# Altium schematic sheet WaterLevelSensor.SchDoc
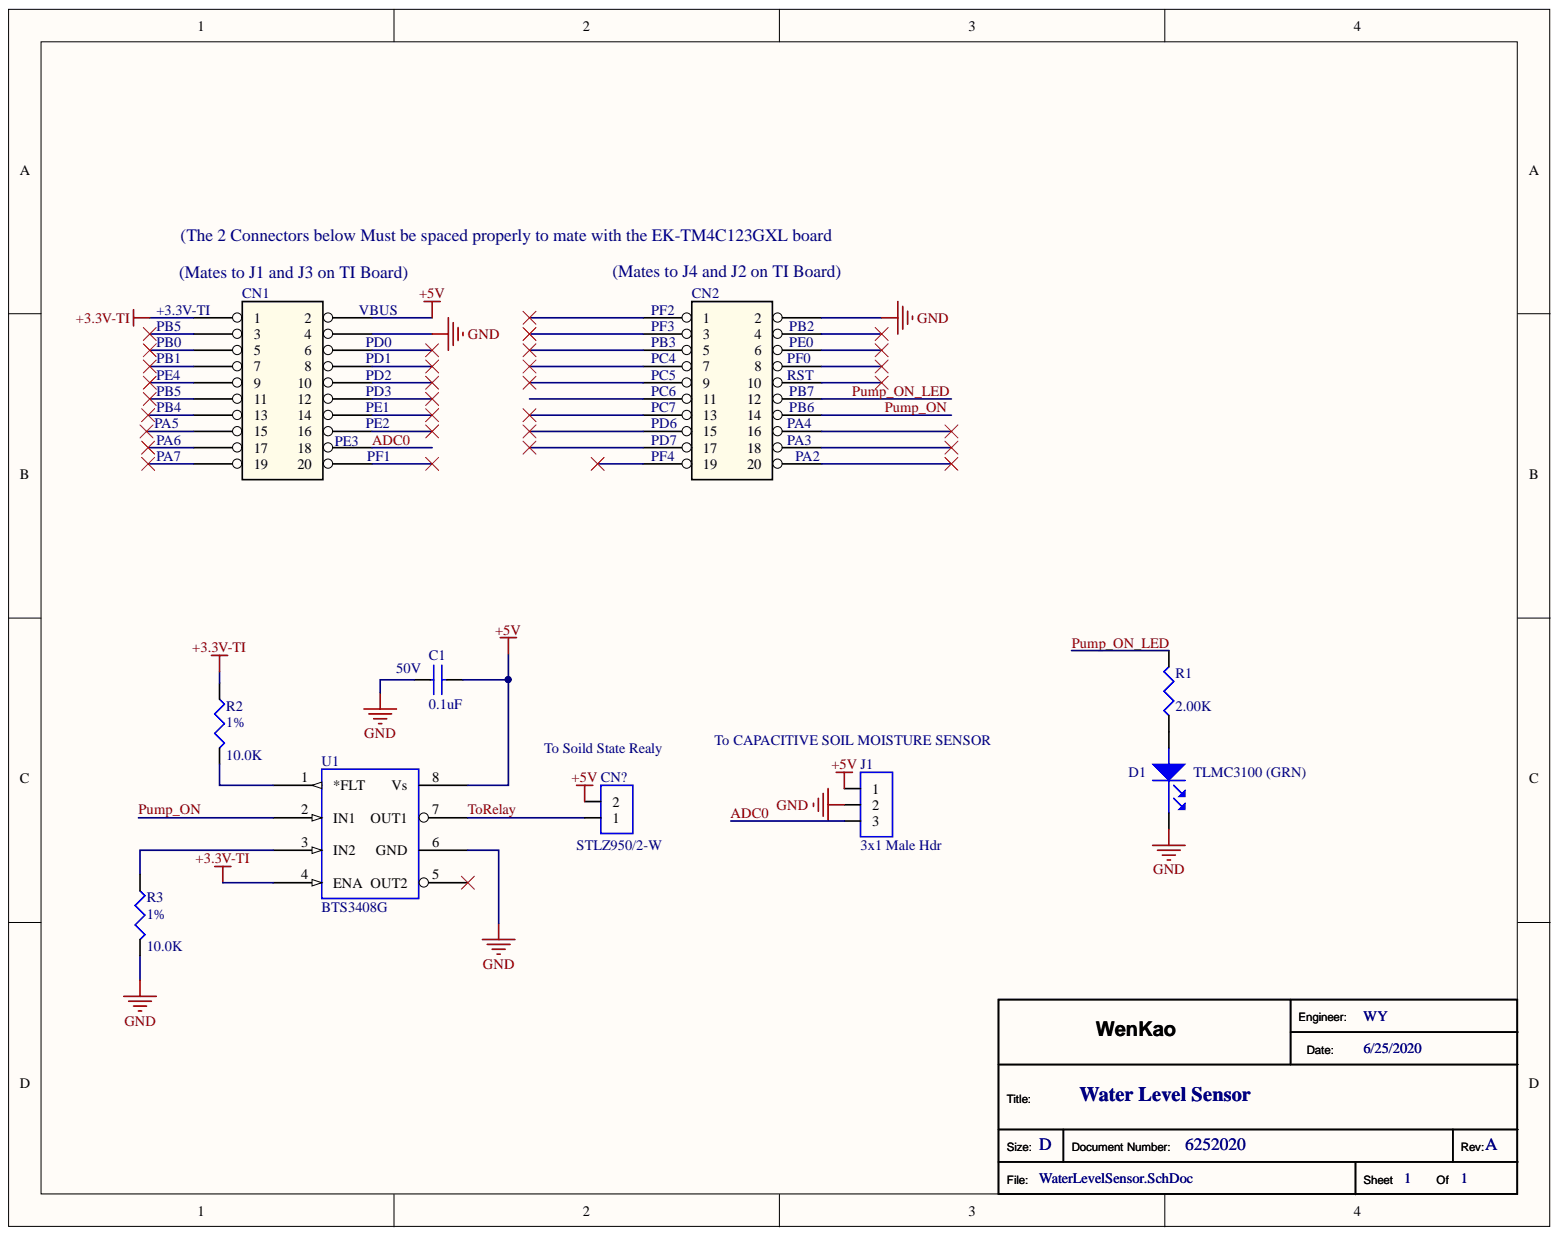
[diagram p0: WaterLevelSensor.SchDoc]

## Parts
C1  0.1uF  Voltage: 50V  [0805]
CN1  HDR 20 Pin  [77324]  (value not drawn)
CN2  HDR 20 Pin  [77324]  (value not drawn)
CN?  Part Number: STLZ950/2-W  [77472l]  (hidden comment: CONN TH HDR MALE 2 x 1, 0.2" R/A 77472 PCB)
D1  TLMC3100 (GRN)  Part Number: TLMC3100  [71072]
J1  3x1 Male Hdr  [70012]
R1  value 2.00K  [0805]  (hidden comment: RES SM D 2.00K 1/10W 0805 1% 01_72577)
R2  value 10.0K  Tolerance: 1%  [0805]  (hidden comment: RES SM E 10.0K 1/10W 0805 1% 72593)
R3  value 10.0K  Tolerance: 1%  [0805]  (hidden comment: RES SM E 10.0K 1/10W 0805 1% 72593)
TitleBlock  TITLE_BLOCK_A  (value not drawn)
U1  BTS3408G  [72343]

## Nets
+3.3V-TI: CN1.1 R2.1 U1.4(ENA)
+5V: C1.2 CN1.2 CN?.2 J1.1 U1.8(Vs)
GND: C1.1 CN1.4 CN2.2 D1.2 J1.2 R3.2 U1.6(GND)
ADC0: CN1.18 J1.3
PUMP_ON: CN2.14 U1.2(IN1)
PUMP_ON_LED: CN2.12 R1.2
TORELAY: CN?.1 U1.7(OUT1)
NetD1_1: D1.1 R1.1
NetR2_2: R2.2 U1.1(*FLT)
NetR3_1: R3.1 U1.3(IN2)

Not connected: CN1 3 5 6 7 8 9 10 11 12 13 14 15 16 17 19 20; CN2 1 3 4 5 6 7 8 9 10 11 13 15 16 17 18 19 20; U1 5(OUT2)

## Library data of the parts
C1 = CAP NP 0.1uf 50V 10% Cer 70969 SMT 0805: Part Name: Cap., SMD, Ceramic, 0805, 50V, 10%, 0.1uF; Part Number: VJ0805Y104KXAM; Vendor PartNo2: 08055C104KAT2A; Vendor PartNo3: 08055C104JAT; Vendor PartNo4: 08052R104K9BB0D; Vendor PartNo5: VJ0805Y104KAMR; ACCOUNT_NUMBER_INVMSTR: 04-001285; BIN_LOCATION_INVMSTR: 24-E-3; BUYER_INVMSTR: 01; CategoryID: 3; CLASS_INVMSTR: CAP; CONTROL_METHOD_INVMSTR: RQ; CONVERSION_INVMSTR: 0; DATE_LAST_COUNT_INVMSTR: 20160104; DATE_LAST_PURCHASED_INVMSTR: 20160425; DESCRIPTION_INVMSTR: CAP 0.1MFD 50V MONLILITC CERAMIC CHIP; Discontinued: False; EXTENDED_TOTAL_INVMSTR: 1455.22; FCI: 70969; LAST_UNIT_COST_INVMSTR: 0.029; LAST_VENDOR_INVMSTR: 108345; LEAD_TIME_INVMSTR: 30; LOCATION_CODE_INVMSTR: 04; REFERENCE_INVMSTR: SYS7; STD_AVG_FLAG_INVMSTR: S; UOM_INVMSTR: EA; USAGE_LAST_YEAR_INVMSTR: 58898; VENDOR_CODE_2_INVMSTR: 106404; VendorID: 222; VendorID2: 239; VendorID4: 61; CATEGORY_INVMSTR: 01; Component Kind: Standard; FootprintType: 0805; FUTURE_EFF_DATE_INVMSTR: 0; FUTURE_STD_COST_INVMSTR: 0; MANUFACT_HOURS_INVMSTR: 0; PHYSICAL_COUNT_INVMSTR: 0; PLANNER_INVMSTR: 01; PRICE_CHANGE_INVMSTR: 15; QUANTITY_OBLIG_INVMSTR: 39768; QUANTITY_ON_HAND_INVMSTR: 50180; QUANTITY_ORDERED_INVMSTR: 0; QUANTITY_REORDER_INVMSTR: 0; QUANTITY_YTD_INVMSTR: 9213; STOCK_LEVEL_MIN_INVMSTR: 0; STOCK_LEVEL_REQ_INVMSTR: 0; UNIT_COST_INVMSTR: 0.029; UNIT_WEIGHT_INVMSTR: 0; Unit Price: 0.01; VENDOR_CODE_1_INVMSTR: 108345; VendorID3: 239; VendorID5: 222; Library Name: FIRECOM_FCI_Library.IntLib
CN1 CN2 = CON HDR MALE 10x2 77324: HDR 0.1'' Male 10x2; ACCOUNT_NUMBER_INVMSTR: 04-001285; BUYER_INVMSTR: 01; CLASS_INVMSTR: CONN; CONTROL_METHOD_INVMSTR: RQ; CONVERSION_INVMSTR: 0; DESCRIPTION_INVMSTR: CON HEADER  - .1" MALE 20 2X10; LEAD_TIME_INVMSTR: 30; LOCATION_CODE_INVMSTR: 04; STD_AVG_FLAG_INVMSTR: S; UOM_INVMSTR: EA; CATEGORY_INVMSTR: 01; Component Kind: Standard; DATE_LAST_COUNT_INVMSTR: 0; DATE_LAST_PURCHASED_INVMSTR: 0; EXTENDED_TOTAL_INVMSTR: 0; FCI: 77324; FUTURE_EFF_DATE_INVMSTR: 0; FUTURE_STD_COST_INVMSTR: 0; LAST_UNIT_COST_INVMSTR: 0; MANUFACT_HOURS_INVMSTR: 0; PHYSICAL_COUNT_INVMSTR: 0; PLANNER_INVMSTR: 01; PRICE_CHANGE_INVMSTR: 0; QUANTITY_OBLIG_INVMSTR: 0; QUANTITY_ON_HAND_INVMSTR: 0; QUANTITY_ORDERED_INVMSTR: 0; QUANTITY_REORDER_INVMSTR: 0; QUANTITY_YTD_INVMSTR: 0; STOCK_LEVEL_MIN_INVMSTR: 0; STOCK_LEVEL_REQ_INVMSTR: 0; UNIT_COST_INVMSTR: 0; UNIT_WEIGHT_INVMSTR: 0; USAGE_LAST_YEAR_INVMSTR: 0; Library Name: FIRECOM_FCI_Library.IntLib
CN? = CONN TH HDR MALE 2 x 1, 0.2" R/A 77472 PCB: FCI: 77472; Component Kind: Standard; Library Name: Wen_NoSpec_Library.SchLib
D1 = LED GRN TLMC3100 71072 SMT: Part Name: LED, SMD, Green, LED-02-3.2X2.4; Vendor PartNo2: VLMP3100; Vendor PartNo3: VLMC3100; ACCOUNT_NUMBER_INVMSTR: 04-001285; BIN_LOCATION_INVMSTR: 05-E-4; BUYER_INVMSTR: 01; CategoryID: 7; CLASS_INVMSTR: DIODE; CONTROL_METHOD_INVMSTR: RQ; CONVERSION_INVMSTR: 0; DATE_LAST_COUNT_INVMSTR: 20160104; DATE_LAST_PURCHASED_INVMSTR: 20150806; DESCRIPTION_INVMSTR: GREEN LED TLMC3100 SMT; Discontinued: False; EXTENDED_TOTAL_INVMSTR: 512.4; LAST_UNIT_COST_INVMSTR: 0.3; LAST_VENDOR_INVMSTR: 108345; LEAD_TIME_INVMSTR: 30; LOCATION_CODE_INVMSTR: 04; REFERENCE_INVMSTR: SYS7; STD_AVG_FLAG_INVMSTR: S; UOM_INVMSTR: EA; USAGE_LAST_YEAR_INVMSTR: 210; VENDOR_CODE_2_INVMSTR: 106404; VendorID: 223; VendorID2: 258; CATEGORY_INVMSTR: 01; Component Kind: Standard; FCI: 71072; FootprintType: P-LCC-2; FUTURE_EFF_DATE_INVMSTR: 0; FUTURE_STD_COST_INVMSTR: 0; MANUFACT_HOURS_INVMSTR: 0; PHYSICAL_COUNT_INVMSTR: 0; PLANNER_INVMSTR: 01; PRICE_CHANGE_INVMSTR: 5; QUANTITY_OBLIG_INVMSTR: 94; QUANTITY_ON_HAND_INVMSTR: 1708; QUANTITY_ORDERED_INVMSTR: 0; QUANTITY_REORDER_INVMSTR: 0; QUANTITY_YTD_INVMSTR: 504; STOCK_LEVEL_MIN_INVMSTR: 0; STOCK_LEVEL_REQ_INVMSTR: 0; UNIT_COST_INVMSTR: 0.3; UNIT_WEIGHT_INVMSTR: 0; Unit Price: 0.22; VENDOR_CODE_1_INVMSTR: 108345; VendorID3: 258; Library Name: FIRECOM_FCI_Library.IntLib
J1 = CON HDR MALE 3x1 .1in 70012: Component Kind: Standard; FCI: 70012; FootprintType: 70012; Library Name: Wen_NoSpec_Library.SchLib
R1 = RES SM D 2.00K 1/10W 0805 1% 01_72577: Part Number: CRCW08052K00FKTA; ACCOUNT_NUMBER_INVMSTR: 04-001285; BIN_LOCATION_INVMSTR: 10-E-4; BUYER_INVMSTR: 01; CategoryID: 2; CONTROL_METHOD_INVMSTR: RQ; CONVERSION_INVMSTR: 0; DATE_LAST_COUNT_INVMSTR: 20160104; DATE_LAST_PURCHASED_INVMSTR: 20111104; DESCRIPTION_INVMSTR: RESISTOR, SMD, 1/10W, 0805, 1%, 2K; Discontinued: False; EXTENDED_TOTAL_INVMSTR: 296.69; FCI: 72577; LAST_UNIT_COST_INVMSTR: 0.028; LAST_VENDOR_INVMSTR: 108345; LEAD_TIME_INVMSTR: 30; LOCATION_CODE_INVMSTR: 04; REFERENCE_INVMSTR: MISC; STD_AVG_FLAG_INVMSTR: S; UOM_INVMSTR: EA; USAGE_LAST_YEAR_INVMSTR: 2154; VENDOR_CODE_2_INVMSTR: 407955; VendorID: 158; CATEGORY_INVMSTR: 01; CLASS_INVMSTR: RES; Component Kind: Standard; ComponentLink1Description: New link; FUTURE_EFF_DATE_INVMSTR: 0; FUTURE_STD_COST_INVMSTR: 0; MANUFACT_HOURS_INVMSTR: 0; Part Name: Resistor, SMD, 1/10W, 0805, 1%, 2K; PHYSICAL_COUNT_INVMSTR: 0; PLANNER_INVMSTR: 01; PRICE_CHANGE_INVMSTR: 3; QUANTITY_OBLIG_INVMSTR: 1800; QUANTITY_ON_HAND_INVMSTR: 10596; QUANTITY_ORDERED_INVMSTR: 0; QUANTITY_REORDER_INVMSTR: 0; QUANTITY_YTD_INVMSTR: 400; Simulation: Model Name; STOCK_LEVEL_MIN_INVMSTR: 0; STOCK_LEVEL_REQ_INVMSTR: 0; Tolerance: 1%; UNIT_COST_INVMSTR: 0.028; UNIT_WEIGHT_INVMSTR: 0; Unit Price: 0.01; VENDOR_CODE_1_INVMSTR: 108345; Library Name: FIRECOM_FCI_Library.SchLib
R2 R3 = RES SM E 10.0K 1/10W 0805 1% 72593: Part Name: Resistor, SMD, 1/10W, 0805, 1%,1 0K; Part Number: CRCW080510K0FKEA; ACCOUNT_NUMBER_INVMSTR: 04-001285; BIN_LOCATION_INVMSTR: 10-E-3; BUYER_INVMSTR: 01; CategoryID: 2; CONTROL_METHOD_INVMSTR: RQ; CONVERSION_INVMSTR: 0; DATE_LAST_COUNT_INVMSTR: 20160104; DATE_LAST_PURCHASED_INVMSTR: 20150320; DESCRIPTION_INVMSTR: RESISTOR, SMD, 1/10W, 0805, 1%, 10K; Discontinued: False; EXTENDED_TOTAL_INVMSTR: 57.41; FCI: 72593; LAST_UNIT_COST_INVMSTR: 0.01; LAST_VENDOR_INVMSTR: 400117; LEAD_TIME_INVMSTR: 30; LOCATION_CODE_INVMSTR: 04; REFERENCE_INVMSTR: MISC; STD_AVG_FLAG_INVMSTR: S; UOM_INVMSTR: EA; USAGE_LAST_YEAR_INVMSTR: 3567; VendorID: 158; CATEGORY_INVMSTR: 01; CLASS_INVMSTR: RES; Component Kind: Standard; FUTURE_EFF_DATE_INVMSTR: 0; FUTURE_STD_COST_INVMSTR: 0; MANUFACT_HOURS_INVMSTR: 0; PHYSICAL_COUNT_INVMSTR: 0; PLANNER_INVMSTR: 01; PRICE_CHANGE_INVMSTR: 2; QUANTITY_OBLIG_INVMSTR: 0; QUANTITY_ON_HAND_INVMSTR: 5741; QUANTITY_ORDERED_INVMSTR: 0; QUANTITY_REORDER_INVMSTR: 0; QUANTITY_YTD_INVMSTR: 1295; Simulation: Model Name; STOCK_LEVEL_MIN_INVMSTR: 0; STOCK_LEVEL_REQ_INVMSTR: 0; UNIT_COST_INVMSTR: 0.01; UNIT_WEIGHT_INVMSTR: 0; Unit Price: 0.01; VENDOR_CODE_1_INVMSTR: 400117; Library Name: FIRECOM_FCI_Library.IntLib
U1 = IC DRIVER BTS3408G 72343 SO8: Part Name: IC SMD Driver Dual Power Switch; ACCOUNT_NUMBER_INVMSTR: 04-001285; BIN_LOCATION_INVMSTR: 10-F-4; BUYER_INVMSTR: 01; CategoryID: 1; CLASS_INVMSTR: IC; CONTROL_METHOD_INVMSTR: RQ; CONVERSION_INVMSTR: 0; DATE_LAST_COUNT_INVMSTR: 20160104; DATE_LAST_PURCHASED_INVMSTR: 20150716; DESCRIPTION_INVMSTR: IC BTS3408G SMD DRIVER DUAL POWER SWITCH; Discontinued: False; EXTENDED_TOTAL_INVMSTR: 2882.52; LAST_UNIT_COST_INVMSTR: 1.57; LAST_VENDOR_INVMSTR: 108854; LEAD_TIME_INVMSTR: 45; LOCATION_CODE_INVMSTR: 04; REFERENCE_INVMSTR: SYS7; STD_AVG_FLAG_INVMSTR: S; UOM_INVMSTR: EA; USAGE_LAST_YEAR_INVMSTR: 289; VENDOR_CODE_2_INVMSTR: 108345; VendorID: 351; CATEGORY_INVMSTR: 01; Component Kind: Standard; FCI: 72343; FUTURE_EFF_DATE_INVMSTR: 0; FUTURE_STD_COST_INVMSTR: 0; MANUFACT_HOURS_INVMSTR: 0; Part Number: BTS3408G; PHYSICAL_COUNT_INVMSTR: 0; PLANNER_INVMSTR: 01; PRICE_CHANGE_INVMSTR: 4; QUANTITY_OBLIG_INVMSTR: 0; QUANTITY_ON_HAND_INVMSTR: 1836; QUANTITY_ORDERED_INVMSTR: 0; QUANTITY_REORDER_INVMSTR: 0; QUANTITY_YTD_INVMSTR: 24; STOCK_LEVEL_MIN_INVMSTR: 0; STOCK_LEVEL_REQ_INVMSTR: 0; UNIT_COST_INVMSTR: 1.57; UNIT_WEIGHT_INVMSTR: 0; VENDOR_CODE_1_INVMSTR: 108854; Library Name: FIRECOM_FCI_Library.IntLib
TitleBlock = TITLE_BLOCK_A: Component Kind: Standard; Library Name: WENTitleBlockLibrary.SchLib
